# Altium schematic sheet CPU.SchDoc
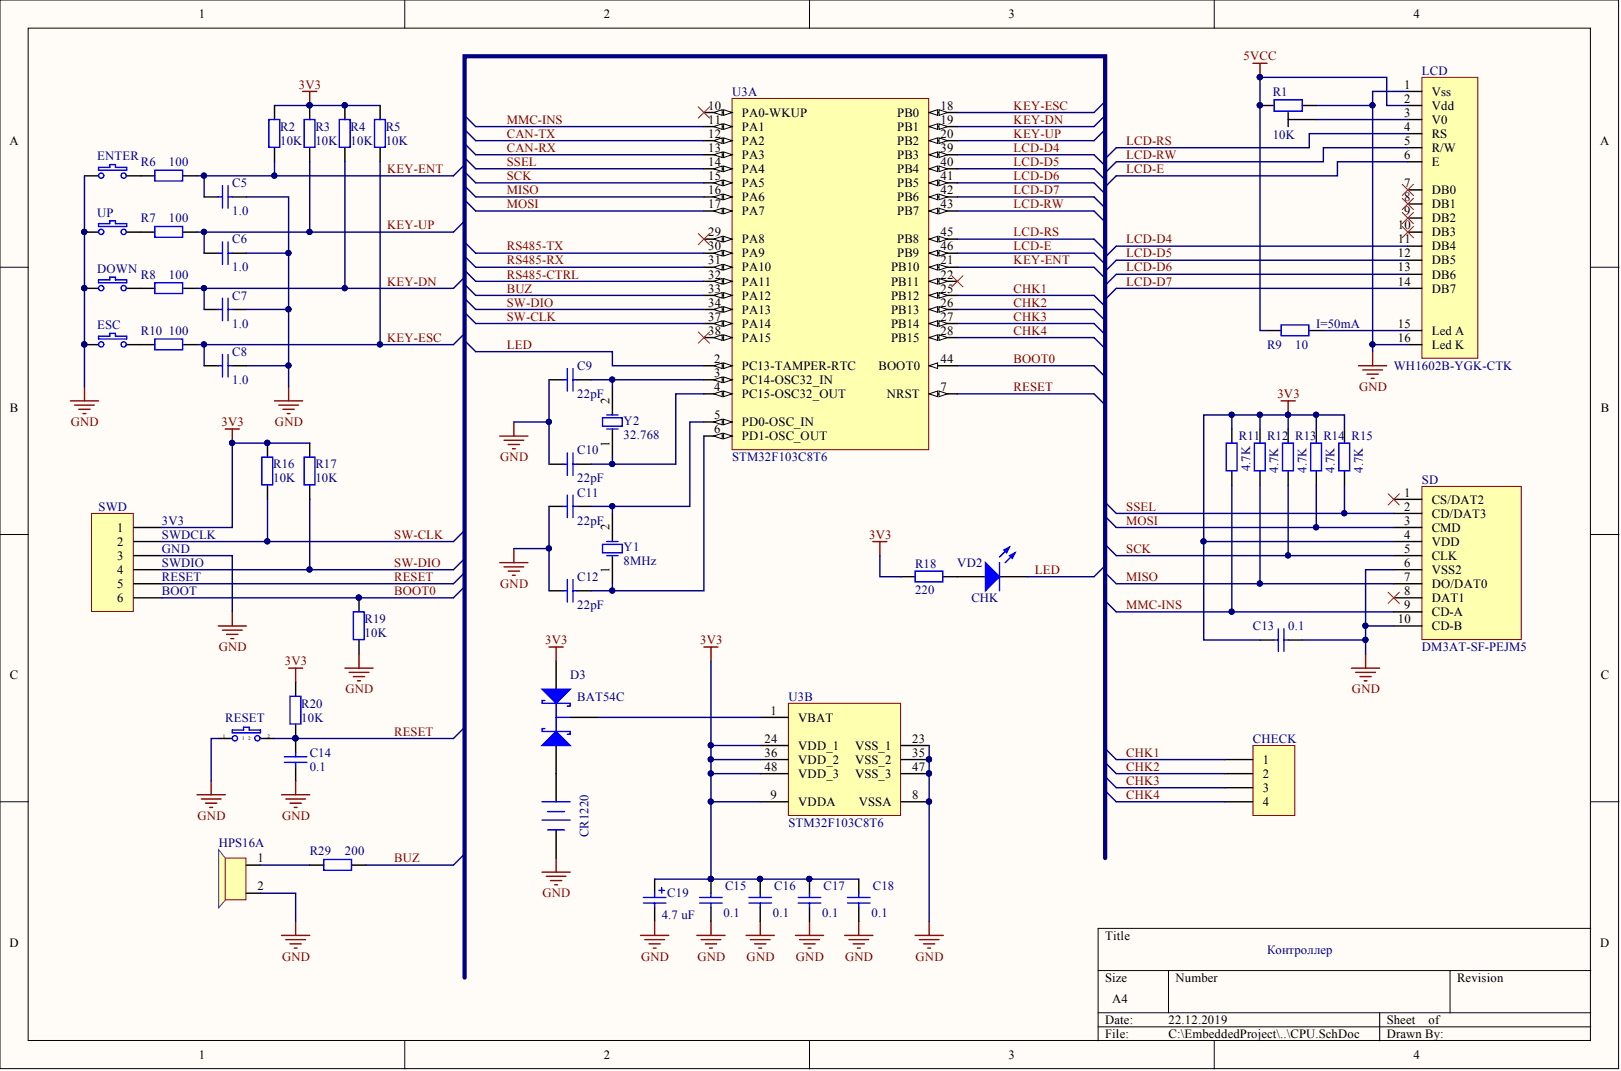
[diagram p0: CPU.SchDoc]

## Parts
BAT  value CR1220  [KLS5-CR1220]  (hidden comment: CR1216,CR1220,CR1225)
C5  value 1.0  [C1206]  (hidden comment: Cap)
C6  value 1.0  [C1206]  (hidden comment: Cap)
C7  value 1.0  [C1206]  (hidden comment: Cap)
C8  value 1.0  [C1206]  (hidden comment: Cap)
C9  value 22pF  [C1206]  (hidden comment: Cap)
C10  value 22pF  [C1206]  (hidden comment: Cap)
C11  value 22pF  [C1206]  (hidden comment: Cap)
C12  value 22pF  [C1206]  (hidden comment: Cap)
C13  value 0.1  [C1206]  (hidden comment: Cap)
C14  value 0.1  [C1206]  (hidden comment: Cap)
C15  value 0.1  [C1206]  (hidden comment: Cap)
C16  value 0.1  [C1206]  (hidden comment: Cap)
C17  value 0.1  [C1206]  (hidden comment: Cap)
C18  value 0.1  [C1206]  (hidden comment: Cap)
C19  value 4.7 uF  [B]  (hidden comment: Polarized Capacitor)
CHECK  Header 4  [HR-1x4]  (value not drawn)
D3  value BAT54C  [SOT23_BAT54]  (hidden comment: Schottky Barrier Double Diode)
DOWN  TS-06  [TS-06]  (value not drawn)
ENTER  KLS7-TS6601-13.0-180  [TS-06]  (value not drawn)
ESC  TS-06  [TS-06]  (value not drawn)
HPS16A  BUZZER  [HPS16A]  (value not drawn)
LCD  WH1602B-YGK-CTK  [AC-162B 2x16]
R1  value 10K  [R Tr 3362H]  (hidden comment: Resistor TAP)
R2  value 10K  [R1206]  (hidden comment: Res2)
R3  value 10K  [R1206]  (hidden comment: Res2)
R4  value 10K  [R1206]  (hidden comment: Res2)
R5  value 10K  [R1206]  (hidden comment: Res2)
R6  value 100  [R1206]  (hidden comment: Res2)
R7  value 100  [R1206]  (hidden comment: Res2)
R8  value 100  [R1206]  (hidden comment: Res2)
R9  value 10  [R1206]  (hidden comment: Res2)
R10  value 100  [R1206]  (hidden comment: Res2)
R11  value 4.7K  [R1206]  (hidden comment: Res2)
R12  value 4.7K  [R1206]  (hidden comment: Res2)
R13  value 4.7K  [R1206]  (hidden comment: Res2)
R14  value 4.7K  [R1206]  (hidden comment: Res2)
R15  value 4.7K  [R1206]  (hidden comment: Res2)
R16  value 10K  [R1206]  (hidden comment: Res2)
R17  value 10K  [R1206]  (hidden comment: Res2)
R18  value 220  [R1206]  (hidden comment: Res2)
R19  value 10K  [R1206]  (hidden comment: Res2)
R20  value 10K  [R1206]  (hidden comment: Res2)
R29  value 200  [R1206]  (hidden comment: Res2)
RESET  KLS7-TS3608-5.0-180  [KLS7-TS3608]  (value not drawn)
SD  DM3AT-SF-PEJM5  [DM3AT-SF-PEJM5]
SWD  Header 6  [HDR1X6]  (value not drawn)
U3  STM32F103C8T6  [STM-LQFP48_N]  (parts A,B)
UP  TS-06  [TS-06]  (value not drawn)
VD2  CHK  [LED1206]
Y1  value 8MHz  [HC49S]  (hidden comment: XTAL)
Y2  value 32.768  [LED]  (hidden comment: XTAL)

## Nets
3V3: C13.1 C15.2 C16.2 C17.2 C18.2 C19.1 D3.2 R2.1 R3.1 R4.1 R5.1 R11.2 R12.2 R13.2 R14.2 R15.2 R16.2 R17.2 R18.1 R20.2 SD.4(VDD) SWD.1 U3.9(VDDA) U3.24(VDD_1) U3.36(VDD_2) U3.48(VDD_3)
5VCC: LCD.2(Vdd) R1.1 R9.1
GND: BAT.2 C5.1 C6.1 C7.1 C8.1 C9.1 C10.1 C11.1 C12.1 C13.2 C14.1 C15.1 C16.1 C17.1 C18.1 C19.2 DOWN.1 ENTER.1 ESC.1 HPS16A.2 LCD.1(Vss) LCD.16(Led_K) R1.3 R19.1 RESET.1 SD.6(VSS2) SD.10(CD-B) SWD.3 U3.8(VSSA) U3.23(VSS_1) U3.35(VSS_2) U3.47(VSS_3) UP.1
BOOT0: R19.2 SWD.6 U3.44(BOOT0)
BUZ: R29.1 U3.33(PA12)
CAN-RX: U3.13(PA3)
CAN-TX: U3.12(PA2)
CHK1: CHECK.1 U3.25(PB12)
CHK2: CHECK.2 U3.26(PB13)
CHK3: CHECK.3 U3.27(PB14)
CHK4: CHECK.4 U3.28(PB15)
KEY-DN: C7.2 R4.2 R8.1 U3.19(PB1)
KEY-ENT: C5.2 R2.2 R6.1 U3.21(PB10)
KEY-ESC: C8.2 R5.2 R10.1 U3.18(PB0)
KEY-UP: C6.2 R3.2 R7.1 U3.20(PB2)
LCD-D4: LCD.11(DB4) U3.39(PB3)
LCD-D5: LCD.12(DB5) U3.40(PB4)
LCD-D6: LCD.13(DB6) U3.41(PB5)
LCD-D7: LCD.14(DB7) U3.42(PB6)
LCD-E: LCD.6(E) U3.46(PB9)
LCD-RS: LCD.4(RS) U3.45(PB8)
LCD-RW: LCD.5(R/W) U3.43(PB7)
LED: U3.2(PC13-TAMPER-RTC) VD2.2
MISO: R12.1 SD.7(DO/DAT0) U3.16(PA6)
MMC-INS: R11.1 SD.9(CD-A) U3.11(PA1)
MOSI: R14.1 SD.3(CMD) U3.17(PA7)
RESET: C14.2 R20.1 RESET.2 SWD.5 U3.7(NRST)
RS485-CTRL: U3.32(PA11)
RS485-RX: U3.31(PA10)
RS485-TX: U3.30(PA9)
SCK: R13.1 SD.5(CLK) U3.15(PA5)
SSEL: R15.1 SD.2(CD/DAT3) U3.14(PA4)
SW-CLK: R16.1 SWD.2 U3.37(PA14)
SW-DIO: R17.1 SWD.4 U3.34(PA13)
NetBAT_1: BAT.1 D3.1
NetC9_2: C9.2 U3.3(PC14-OSC32_IN) Y2.2
NetC10_2: C10.2 U3.4(PC15-OSC32_OUT) Y2.1
NetC11_2: C11.2 U3.5(PD0-OSC_IN) Y1.2
NetC12_2: C12.2 U3.6(PD1-OSC_OUT) Y1.1
NetD3_3: D3.3 U3.1(VBAT)
NetDOWN_2: DOWN.2 R8.2
NetENTER_2: ENTER.2 R6.2
NetESC_2: ESC.2 R10.2
NetHPS16A_1: HPS16A.1 R29.2
NetLCD_3: LCD.3(V0) R1.2
NetLCD_15: LCD.15(Led_A) R9.2
NetR7_2: R7.2 UP.2
NetR18_2: R18.2 VD2.1
N00037:

Not connected: LCD 7(DB0) 8(DB1) 9(DB2) 10(DB3); SD 1(CS/DAT2) 8(DAT1); U3 10(PA0-WKUP) 22(PB11) 29(PA8) 38(PA15)

## Library data of the parts
BAT = Battery: Multicell Battery; DeliveryTime: 7; Component Kind: Standard; Library Name: Miscellaneous Devices.IntLib; PartNumber: CR1216; Price: 42.00
C5-C13 = Cap: Capacitor; Component Kind: Standard; LatestRevisionDate: 17-Jul-2002; LatestRevisionNote: Re-released for DXP Platform.; Library Name: Miscellaneous Devices.IntLib; PackageReference: RAD-0.3; Published: 8-Jun-2000; Signal Integrity: Cap; Simulation: CAP; Publisher: Altium Limited
C14-C18 = Cap: Capacitor; PartNumber: GRM31CR72A104K; DeliveryTime: 7; Component Kind: Standard; Library Name: Miscellaneous Devices.IntLib; Price: 10.00; Signal Integrity: Cap; Simulation: CAP
C19 = Cap Pol2: PartNumber: TECAP, 4.7мкф, 16В, тип В, 10% SMD; DeliveryTime: 7; Value: 4.7 uF; Component Kind: Standard; Description: Polarized Capacitor; Library Name: Miscellaneous Devices.IntLib; Price: 12.00; Signal Integrity: Cap Pol; Simulation: CAP
CHECK = Header 4: Header, 4-Pin; Component Kind: Standard; LatestRevisionDate: 17-Jul-2002; LatestRevisionNote: Re-released for DXP Platform.; Library Name: Miscellaneous Connectors.IntLib; Signal Integrity: Connector; Publisher: Altium Limited
D3 = BAT54C: DeliveryTime: 7; Component Kind: Standard; Description: Schottky Barrier Double Diode; Library Name: Philips Discrete Diode - Schottky.IntLib; PartNUmber: BAT54C; Price: 4.00; Signal Integrity: BAT54C; Simulation: BAT54C
DOWN ENTER ESC RESET UP = Buton TS-06: Component Kind: Standard; Library Name: Devise.IntLib; Price: 0.15
LCD = AC162B 2x16: Component Kind: Standard; Library Name: Ind LED-LCD.IntLib
R1 = Res TAP: Code_IPC: RESC2012; Component Kind: Standard; Description: Resistor TAP; LatestRevisionDate: 29-May-2009; LatestRevisionNote: IPC-7351 Footprint Added.; Library Name: Schlib1.SchLib; PackageDescription: Chip Resistor 0805 Case Size; PackageReference: 6-0805; PackageVersion: Mar-2003; Published: 24-Mar-1999; Publisher: Altium Limited
R2-R15 R29 = Res2: Resistor; Component Kind: Standard; LatestRevisionDate: 17-Jul-2002; LatestRevisionNote: Re-released for DXP Platform.; Library Name: Miscellaneous Devices.IntLib; PackageReference: AXIAL-0.4; Published: 8-Jun-2000; Signal Integrity: Res; Simulation: RESISTOR; Publisher: Altium Limited
R16 R17 R19 R20 = Res2: Resistor; PartNumber: RC1206JK-0710KL; DeliveryTime: 7; Component Kind: Standard; Library Name: Miscellaneous Devices.IntLib; Price: 0.90; Signal Integrity: Res; Simulation: RESISTOR
R18 = Res2: Resistor; PartNumber: RC1206JK-07220RL; DeliveryTime: 7; Component Kind: Standard; Library Name: Miscellaneous Devices.IntLib; Price: 0.90; Signal Integrity: Res; Simulation: RESISTOR
SD = DM3AT-SF-PEJM5: SD Slot; Component Kind: Standard; Library Name: Schlib1.SchLib
SWD = Header 6: Header, 6-Pin; Component Kind: Standard; LatestRevisionDate: 17-Jul-2002; LatestRevisionNote: Re-released for DXP Platform.; Library Name: Miscellaneous Connectors.IntLib; Signal Integrity: Connector; Publisher: Altium Limited
U3 = STM32F103C8T6: ARM Cortex-M3 32-bit MCU, 64 KB Flash, 20 KB Internal RAM, 37 I/Os, 48-pin LQFP, -40 to 85 degC, Tray; A/D Converter: 2x12-bit (10 Channel); FLASH Size (Prog) (kB): 64; I/Os (High Current): 37; Internal RAM Size (kB): 20; Operating Frequency(F) (Processor speed) (MHz): 72; Supply Voltage(Vcc) min (V): 2; Component Kind: Standard; ComponentLink1Description: Manufacturer URL; ComponentLink1URL: http://www.st.com/web/en/home.html; ComponentLink2Description: Datasheet; ComponentLink2URL: http://www.st.com/st-web-ui/static/active/en/resource/technical/document/datasheet/CD00161566.pdf; ComponentLink3Description: Package Specification; ComponentLink3URL: http://www.st.com/st-web-ui/static/active/en/resource/technical/document/datasheet/DM00058181.pdf; Core: ARM Cortex-M3; DatasheetVersion: Rev. 16; Library Name: STMicroelectronics STM32 F1.IntLib; Mounting Technology: Surface Mount; PackageDescription: 48-Pin Low Profile Quad Flat Package, 7 x 7 mm Body, 0.5 mm Pitch; PackageReference: LQFP48; PackageVersion: Rev. 6, 06/2013; Packing: Tray; PartNumber: STM32F103C8T6; Supplier 1: Digi-Key; Supplier 2: Farnell; Supplier 3: Newark; Supplier 4: Newark; Supplier 5: Mouser; Supplier Part Number 1: 497-6063-ND; Supplier Part Number 2: 1447637; Supplier Part Number 3: 59M2182; Supplier Part Number 4: 57P1222; Supplier Part Number 5: 511-STM32F103C8T6
VD2 = LED0: SMD LED; PartNumber: KPDT-3216PGC; DeliveryTime: 7; value: GREEN; Component Kind: Standard; Library Name: Miscellaneous Devices.IntLib; Price: 9.00; Simulation: LED0
Y1 = XTAL: Crystal Oscillator; PartNumber: LFXTAL020423; DeliveryTime: 21; Component Kind: Standard; Library Name: Miscellaneous Devices.IntLib; Price: 57.00; Simulation: XTAL
Y2 = XTAL: Crystal Oscillator; PartNumber: MCRJ332768F1220HOW; DeliveryTime: 21; Component Kind: Standard; Library Name: Miscellaneous Devices.IntLib; Price: 28; Simulation: XTAL
HPS16A = BUZZER: Component Kind: Standard; Library Name: Schlib1.SchLib
